# Altium schematic sheet karmanDB_camera.SchDoc
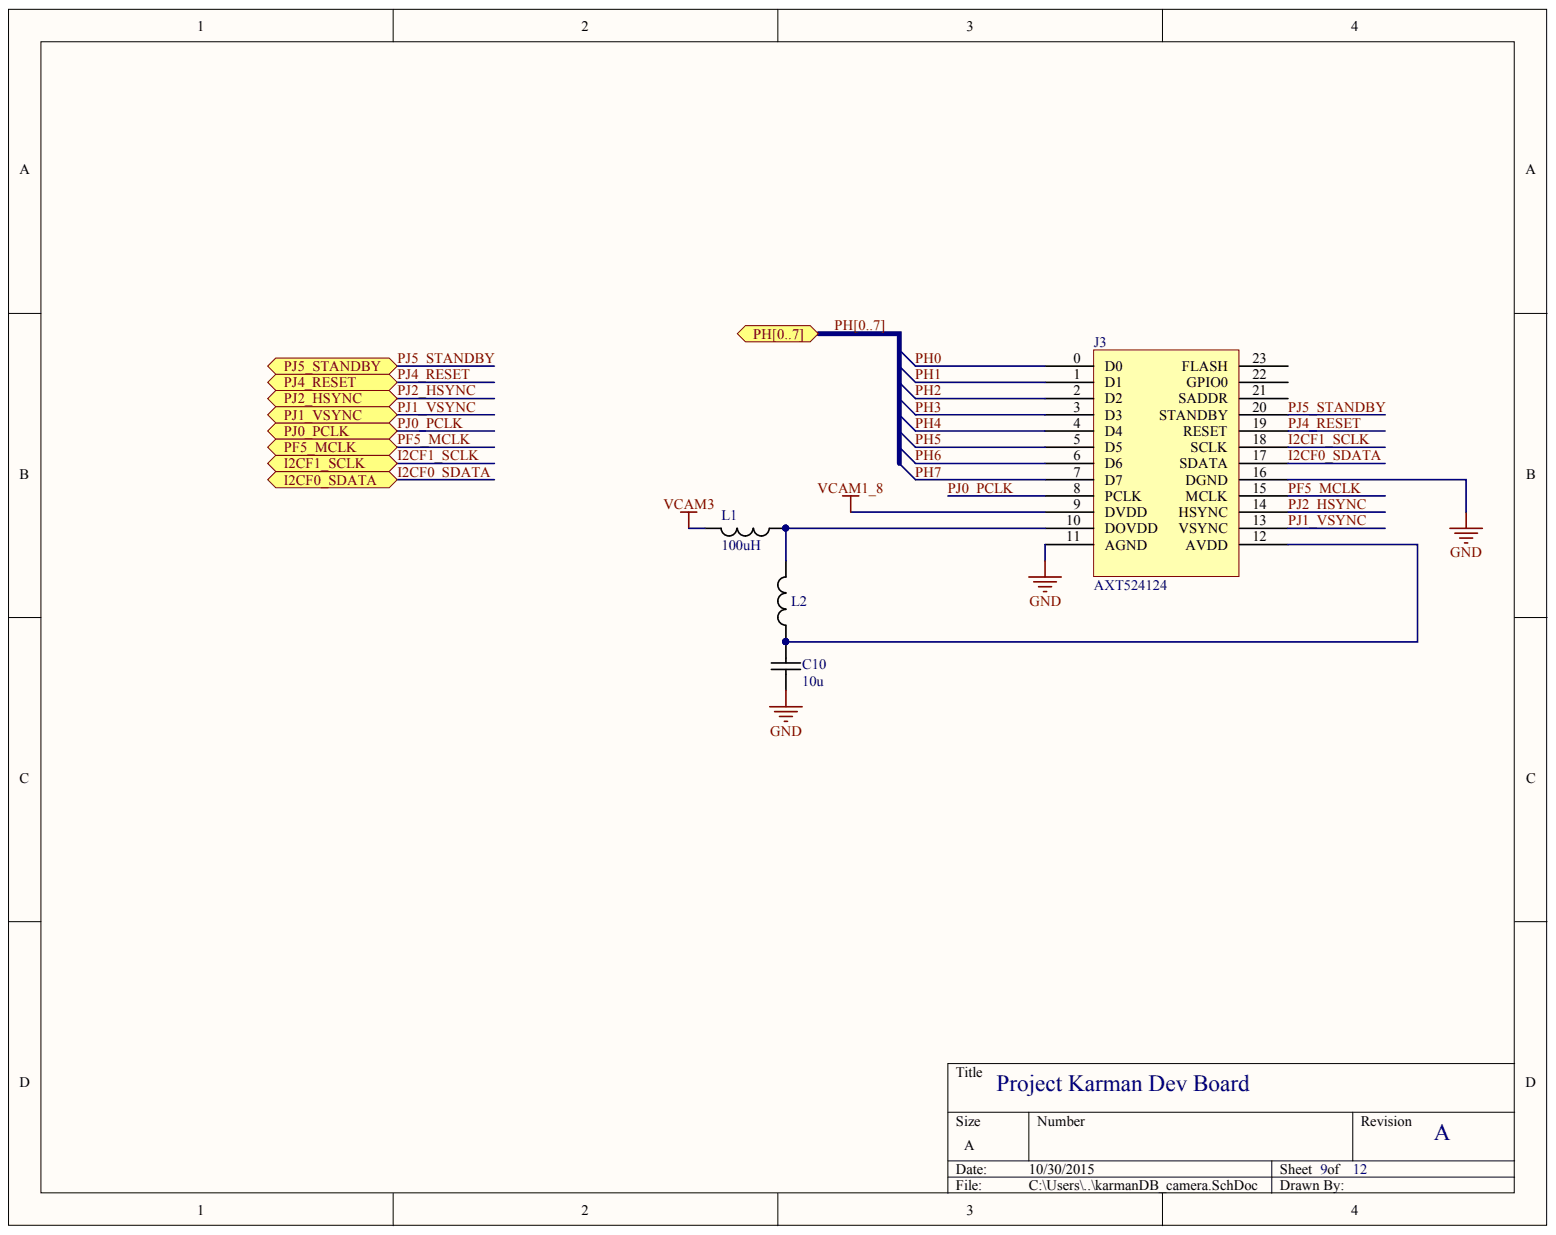
[diagram p0: karmanDB_camera.SchDoc]

## Parts
C10  10u  [MURATA_GRM_0603]
J3  AXT524124
L1  100uH
L2  BLM15BB221SN1D  (value not drawn)

## Ports
I2CF0_SDATA, I2CF1_SCLK, PF5_MCLK, PH0, PH1, PH2, PH3, PH4, PH5, PH6, PH7, PH[0..7], PJ0_PCLK, PJ1_VSYNC, PJ2_HSYNC, PJ4_RESET, PJ5_STANDBY

## Nets
GND: C10.2 J3.11(AGND) J3.16(DGND)
VCAM1_8: J3.9(DVDD)
VCAM3: L1.2
I2CF0_SDATA: J3.17(SDATA) ; port I2CF0_SDATA
I2CF1_SCLK: J3.18(SCLK) ; port I2CF1_SCLK
PF5_MCLK: J3.15(MCLK) ; port PF5_MCLK
PH0: J3.0(D0) ; port PH[0..7]  [also labelled PH]
PH1: J3.1(D1) ; port PH[0..7]  [also labelled PH]
PH2: J3.2(D2) ; port PH[0..7]  [also labelled PH]
PH3: J3.3(D3) ; port PH[0..7]  [also labelled PH]
PH4: J3.4(D4) ; port PH[0..7]  [also labelled PH]
PH5: J3.5(D5) ; port PH[0..7]  [also labelled PH]
PH6: J3.6(D6) ; port PH[0..7]  [also labelled PH]
PH7: J3.7(D7) ; port PH[0..7]  [also labelled PH]
PJ0_PCLK: J3.8(PCLK) ; port PJ0_PCLK
PJ1_VSYNC: J3.13(VSYNC) ; port PJ1_VSYNC
PJ2_HSYNC: J3.14(HSYNC) ; port PJ2_HSYNC
PJ4_RESET: J3.19(RESET) ; port PJ4_RESET
PJ5_STANDBY: J3.20(STANDBY) ; port PJ5_STANDBY
NetC10_1: C10.1 J3.12(AVDD) L2.1
NetJ3_10: J3.10(DOVDD) L1.1 L2.2

Not connected: J3 21(SADDR) 22(GPIO0) 23(FLASH)

## Library data of the parts
C10 = CAP_MURATA_GRM188R60J106: CAP CER 10UF 6.3V 20% X5R 0603; Manufacturer: Murata Electronics North America; Manufacturer Part Number: GRM188R60J106ME47J; Capacitance: 10µF; Applications: General Purpose; Category: Capacitors; Component Kind: Standard; ComponentLink1Description: http://www.murata.com/~/media/webrenewal/support/library/catalog/products/capacitor/mlcc/c02e.ashx; ComponentLink1URL: http://www.murata.com/~/media/webrenewal/support/library/catalog/products/capacitor/mlcc/c02e.ashx; Features: -; Height - Seated (Max): -; Lead Spacing: -; Lead Style: -; Library Name: project_symbols.SchLib; Mounting Type: Surface Mount, MLCC; Operating Temperature: -55°C ~ 85°C; Package / Case: 0603 (1608 Metric); Packaging: Cut Tape (CT); Pricing: 1=0.19, 10=0.128, 50=0.0776, 100=0.0651, 250=0.05272, 500=0.0465, 1000=0.03953, 5000=0.03333 (USD); Ratings: -; RoHS: RoHS Compliant; Size / Dimension: 0.063" L x 0.031" W (1.60mm x 0.80mm); Stock 1: 159118; Supplier 1: Digi-Key; Supplier Part Number 1: 490-6405-1-ND; Temperature Coefficient: X5R; Thickness (Max): 0.035" (0.90mm); Tolerance: ±20%; Voltage - Rated: 6.3V
J3 = AXT524124: CONN SOCKET F4S .4MM 24POS SMD; Manufacturer: Panasonic Electric Works; Connector Type: Socket, Outer Shroud Contacts; Mated Stacking Heights: 1mm; Number of Positions: 24; Pitch: 0.016" (0.40mm); Category: Connectors, Interconnects; Component Kind: Standard; ComponentLink1Description: http://www3.panasonic.biz/ac/e_download/control/connector/base-fpc/catalog/con_eng_f4s.pdf; ComponentLink1URL: http://www3.panasonic.biz/ac/e_download/control/connector/base-fpc/catalog/con_eng_f4s.pdf; Contact Finish: Gold; Contact Finish Thickness: -; Features: Solder Retention; Height Above Board: 0.038" (0.97mm); Manufacturer Part Number: AXT524124; Mounting Type: Surface Mount; Number of Rows: 2; Packaging: Cut Tape (CT); Pricing 1: 1=2.29, 10=1.901, 100=1.408, 250=1.2352, 500=1.1264 (USD); RoHS: RoHS Compliant; Stock 1: 1416; Supplier 1: Digi-Key; Supplier Part Number 1: 255-2411-1-ND; Library Name: karman_pcb.SCHLIB
L1 = PANASONIC_ELL-VGG100M: FIXED IND 10UH 730MA 280 MOHM; Manufacturer: Panasonic Electronic Components; Current - Saturation: 800mA; Frequency - Test: 100kHz; Inductance: 10µH; Shielding: Shielded; Tolerance: ±20%; Type: Wirewound; Category: Inductors, Coils, Chokes; Component Kind: Standard; ComponentLink1Description: http://industrial.panasonic.com/www-cgi/jvcr13pz.cgi?E+PZ+2+AGM0001+0+4+WW; ComponentLink1URL: http://industrial.panasonic.com/www-cgi/jvcr13pz.cgi?E+PZ+2+AGM0001+0+4+WW; Current Rating: 730mA; DC Resistance (DCR): 280 mOhm; Frequency - Self Resonant: -; Height - Seated (Max): 0.059" (1.50mm); Library Name: project_symbols.SchLib; Manufacturer Part Number: ELL-VGG100M; Material - Core: -; Mounting Type: Surface Mount; Operating Temperature: -; Package / Case: Nonstandard; Packaging: Cut Tape (CT); Pricing 1: 1=0.48, 10=0.455, 50=0.4264, 100=0.398, 500=0.31268 (USD); Q @ Freq: -; RoHS: RoHS Compliant; Size / Dimension: 0.118" L x 0.118" W (3.00mm x 3.00mm); Stock 1: 21071; Supplier 1: Digi-Key; Supplier Device Package: -; Supplier Part Number 1: PCD2304CT-ND
L2 = BLM15BB221SN1D: FERRITE CHIP 220 OHM 200MA 0402; Manufacturer: Murata Electronics North America; DC Resistance (DCR): 800 mOhm Max; Filter Type: Differential Mode - Single; Impedance @ Frequency: 220 Ohm @ 100MHz; Category: Filters; Component Kind: Standard; ComponentLink1Description: http://search.murata.co.jp/Ceramy/image/img/PDF/ENG/L0110S0100BLM15B.pdf; ComponentLink1URL: http://search.murata.co.jp/Ceramy/image/img/PDF/ENG/L0110S0100BLM15B.pdf; Current Rating: 200mA; Height (Max): 0.022" (0.55mm); Manufacturer Part Number: BLM15BB221SN1D; Mounting Type: Surface Mount; Package / Case: 0402 (1005 Metric); Packaging: Cut Tape (CT); Pricing 1: 1=0.1, 10=0.083, 50=0.0544, 100=0.047, 250=0.03712, 500=0.03136, 1000=0.0264, 5000=0.02351 (USD); RoHS: RoHS Compliant; Size / Dimension: 0.039" L x 0.020" W (1.00mm x 0.50mm); Stock 1: 37626; Supplier 1: Digi-Key; Supplier Part Number 1: 490-5190-1-ND; Library Name: karman_pcb.SCHLIB
